# Altium schematic sheet Peripherals.SchDoc
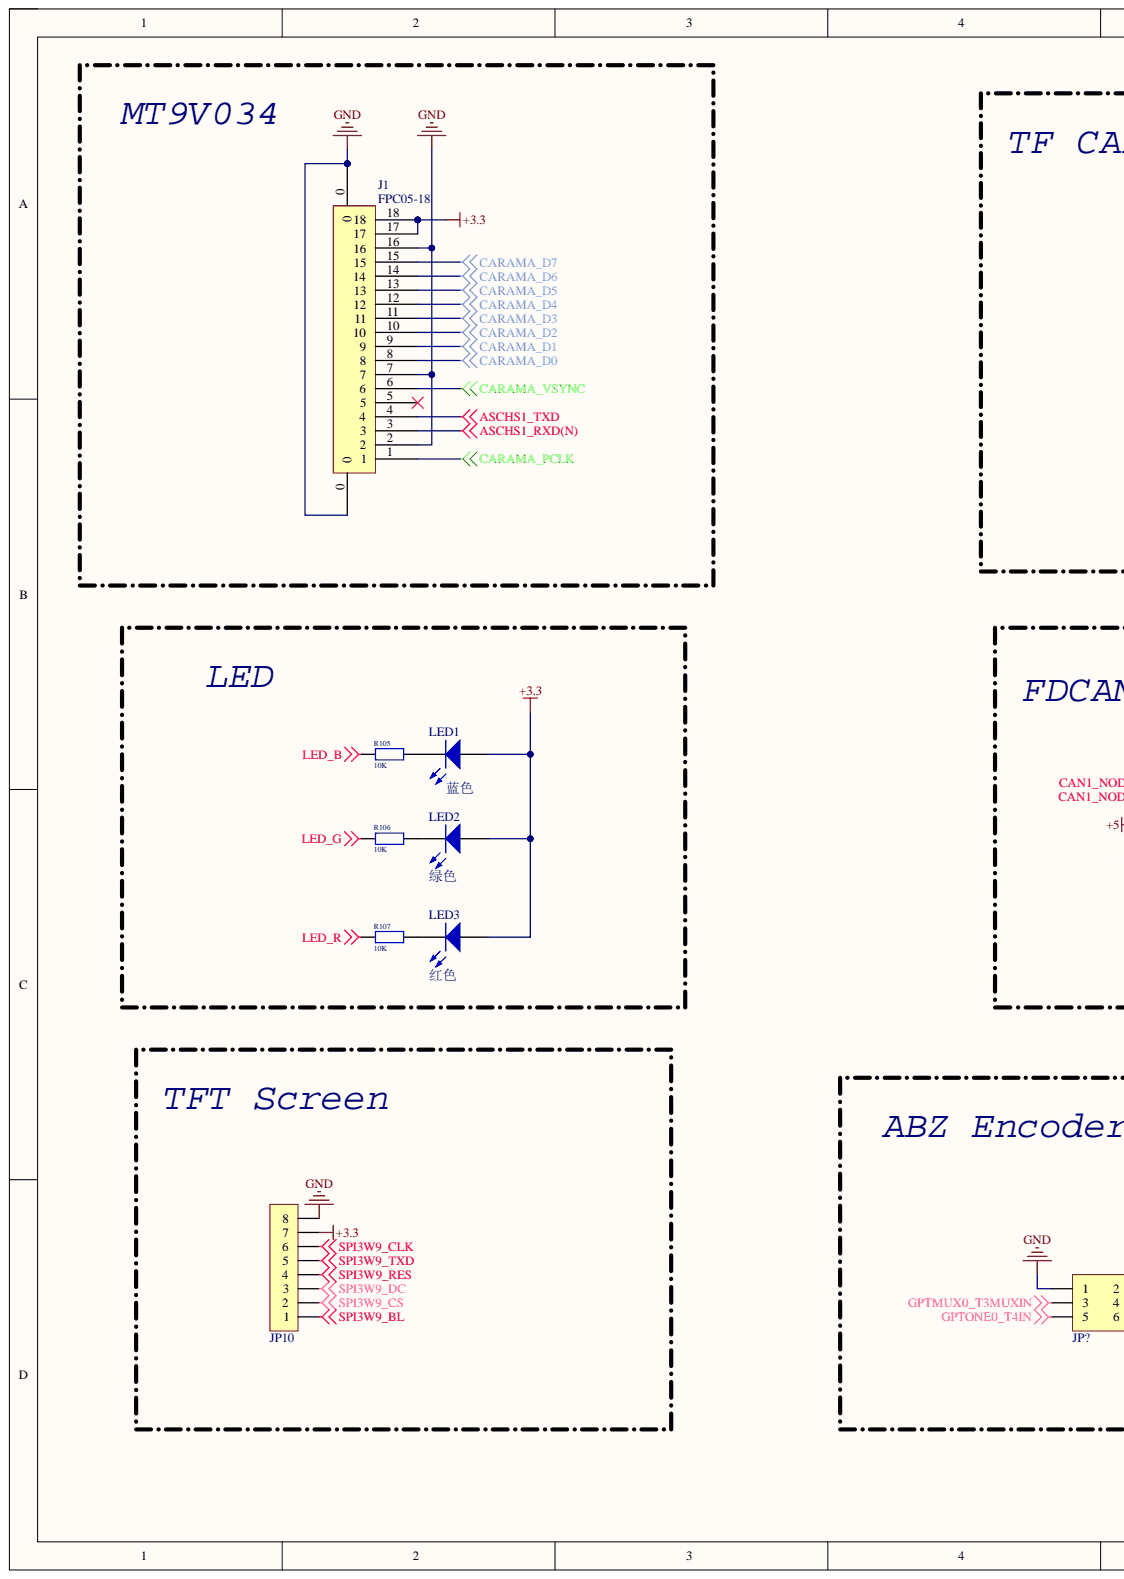
[diagram p0: Peripherals.SchDoc, left]
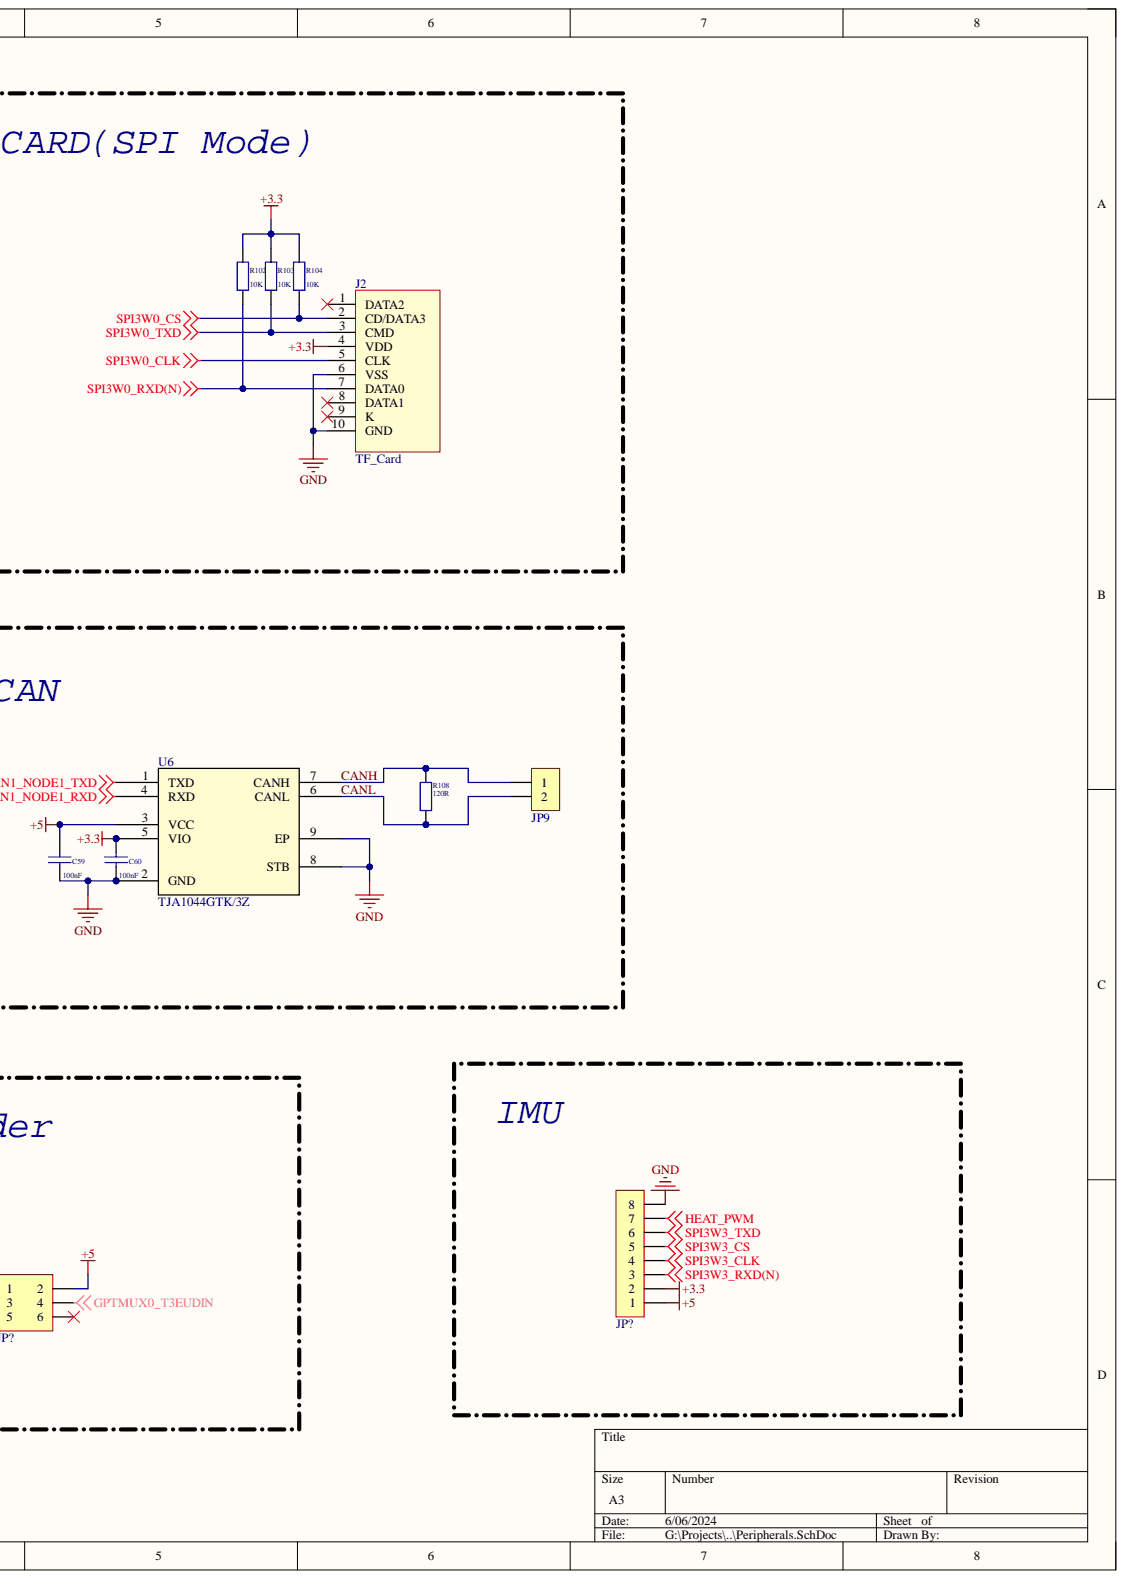
[diagram p1: Peripherals.SchDoc, right]

## Parts
C59  100nF  [C0402_L]
C60  100nF  [C0402_L]
J1  FPC05-18  [fpc_05_18p]
J2  TF_Card  [Micro SD]
JP9  HDR-1X2  [GH1.25-WT-2P]  (value not drawn)
JP10  HDR-1X8  [MX1.25-WT-8P]  (value not drawn)
JP?  HDR-1X8  [MX1.25-WT-8P]  (value not drawn)
JP?  Header3X2  [IDC2.54-LI-2x3P]  (value not drawn)
LED1  蓝色  [LED0402_B]
LED2  绿色  [LED0402_G]
LED3  红色  [LED0402_R]
R102  10K  [R0402_L]
R103  10K  [R0402_L]
R104  10K  [R0402_L]
R105  10K  [R0402_L]
R106  10K  [R0402_L]
R107  10K  [R0402_L]
R108  120R  [R0402_L]
U6  TJA1044GTK/3Z  [SON8_TJA1044GTK3Z_NXP]

## Nets
+3.3: C60.2 J1.17 J1.18 J2.4(VDD) JP10.7 JP?.2 LED1.1 LED2.1 LED3.1 R102.2 R103.2 R104.2 U6.5(VIO)
+5: C59.2 JP?.1 JP?.2 U6.3(VCC)
ASCHS1_RXD(N): J1.3
ASCHS1_TXD: J1.4
CAN1_NODE1_RXD: U6.4(RXD)
CAN1_NODE1_TXD: U6.1(TXD)
CARAMA_D0: J1.8
CARAMA_D1: J1.9
CARAMA_D2: J1.10
CARAMA_D3: J1.11
CARAMA_D4: J1.12
CARAMA_D5: J1.13
CARAMA_D6: J1.14
CARAMA_D7: J1.15
CARAMA_PCLK: J1.1
CARAMA_VSYNC: J1.6
GND: C59.1 C60.1 J1.0 J1.2 J1.7 J1.16 J2.6(VSS) J2.10(GND) JP10.8 JP?.1 JP?.8 U6.2(GND) U6.8(STB) U6.9(EP)
GPTMUX0_T3EUDIN: JP?.4
GPTMUX0_T3MUXIN: JP?.3
GPTONE0_T4IN: JP?.5
HEAT_PWM: JP?.7
LED_B: R105.2
LED_G: R106.2
LED_R: R107.2
SPI3W0_CLK: J2.5(CLK)
SPI3W0_CS: J2.2(CD/DATA3) R104.1
SPI3W0_RXD(N): J2.7(DATA0) R102.1
SPI3W0_TXD: J2.3(CMD) R103.1
SPI3W3_CLK: JP?.4
SPI3W3_CS: JP?.5
SPI3W3_RXD(N): JP?.3
SPI3W3_TXD: JP?.6
SPI3W9_BL: JP10.1
SPI3W9_CLK: JP10.6
SPI3W9_CS: JP10.2
SPI3W9_DC: JP10.3
SPI3W9_RES: JP10.4
SPI3W9_TXD: JP10.5
CANH: JP9.1 R108.1 U6.7(CANH)
CANL: JP9.2 R108.2 U6.6(CANL)
NetLED1_2: LED1.2 R105.1
NetLED2_2: LED2.2 R106.1
NetLED3_2: LED3.2 R107.1

Not connected: J1 5; J2 1(DATA2) 8(DATA1) 9(K)

## Library data of the parts
C59 C60 = CMP-002-000000-1: 贴片电容/MLCC电容; BOM名称: 无极性贴片电容; 当前库版本V2023.5.31 @淘宝卖家: 最新版本发布https://item.taobao.com/item.htm?id=566775463719; Component Kind: Standard; Design Item ID: C; Source: 0.1 - 电阻-电容-电感-保险丝-晶振-晶体-磁珠-电位器-法拉电容-馈通电容.SchLib; Library Name: 海南大学MECHA战队
J2 = TF_Card: TF卡座; 当前库版本V2023.5.31 @淘宝卖家: 最新版本发布https://shop463601444.taobao.com; BOM名称: TF卡座; Component Kind: Standard; Library Name: 0.6 - 电源_音频_USB Type-C_HDMI_XT60_5557_D-SUB_RJ45_SD-TF_SIM插座.SchLib
JP9 = Header 2: 2P连接器; 联系卖家 免费技术支持: 封装库来自淘宝卖家ID：黄晶宝石; BOM名称: 2P连接器; Component Kind: Standard; Library Name: 5.1 - 1.25mm连接器(FPC1.25_GH1.25_MX1.25).SchLib
JP10 JP? = Header 8: 8P连接器; 联系卖家 免费技术支持: 封装库来自淘宝卖家ID：黄晶宝石; BOM名称: 8P连接器; Component Kind: Standard; Library Name: 5.1 - 1.25mm连接器(FPC1.25_GH1.25_MX1.25).SchLib
JP? = Header 3X2: 3*2P接插件; 封装库来自淘宝卖家ID：黄晶宝石: 其他店铺购入均为盗版封装库，请立即申请退款，本店现金奖励50~200元; 联系卖家，免费查找封装: 唯一出售店铺网址https://shop463601444.taobao.com; BOM名称: 3*2P接插件; Component Kind: Standard; Library Name: 5.5 - 2.54mm连接器(KF2EDGK_XH2.54_2.54排针排母).SchLib
LED1-LED3 = LED: 贴片LED; 封装库来自淘宝卖家：黄晶宝石: 其他店铺购入均为盗版封装库，无更新权益，请立即申请退款，本店现金奖励200~1000元; BOM名称: 贴片LED; Component Kind: Standard; Library Name: 0.3 - LED发光二极管-IR二极管_接收管-光耦.SchLib
R102-R108 = CMP-005-000000-2: 贴片电阻0; BOM名称: 贴片电阻; 联系下单店铺卖家 免费帮你查找封装: 联系下单店铺卖家 免费技术支持; Component Kind: Standard; Design Item ID: R; Source: 0.1 - 电阻-电容-电感-保险丝-晶振-晶体-磁珠-电位器-法拉电容-馈通电容.SchLib; Library Name: 海南大学MECHA战队
U6 = CMP-00028-4: CAN High Speed Transceiver 1Mbps Normal/Standby 4.75V to 5.25V 8-Pin HVSON T/R; Component Kind: Standard; Library Name: 海南大学MECHA战队
J1 = FPC05-18: Component Kind: Standard; Library Name: FPC18.SchLib
